# Altium schematic sheet Sheet_all.SchDoc(Sheet_all)
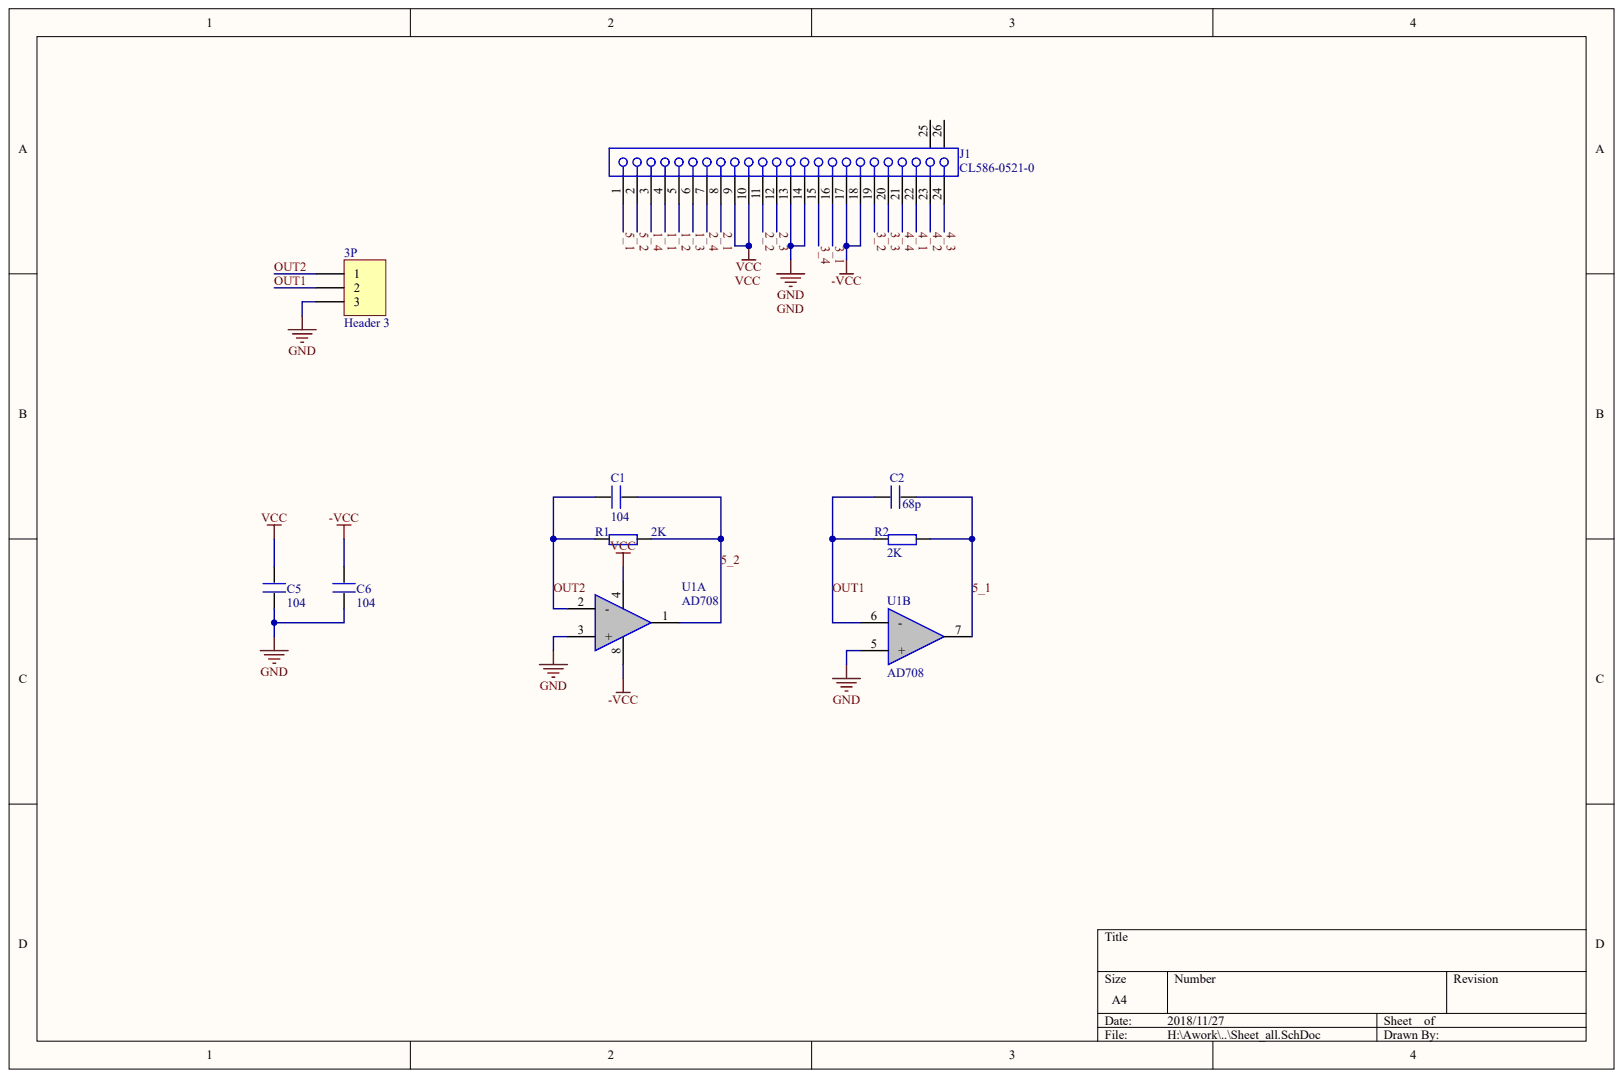
[diagram p0: Sheet_all.SchDoc]

## Parts
3P  Header 3
C1  104
C2  68p
C5  104
C6  104
J1  CL586-0521-0
R1  2K
R2  2K
U1  AD708  (parts A,B)

## Nets
-VCC: C6.2 J1.17 J1.18 U1.8
1_1: J1.4
1_2: J1.5
1_3: J1.6
1_4: J1.3
2_1: J1.8
2_2: J1.11
2_3: J1.12
2_4: J1.7
3_1: J1.16
3_2: J1.19
3_3: J1.20
3_4: J1.15
4_1: J1.22
4_2: J1.23
4_3: J1.24
4_4: J1.21
5_1: C2.2 J1.1 R2.2 U1.7
5_2: C1.2 J1.2 R1.2 U1.1
GND: 3P.3 C5.1 C6.1 J1.13 J1.14 U1.3(+) U1.5(+)
OUT1: 3P.2 C2.1 R2.1 U1.6(-)
OUT2: 3P.1 C1.1 R1.1 U1.2(-)
VCC: C5.2 J1.9 J1.10 U1.4

Not connected: J1 25 26

## Library data of the parts
J1 = (no symbol name): Positions: 24; ContactMaterial: Phosphor Bronze; Current: 0.4A; PCB_Layout: Complies with manufacturer's recommendation.; Published: 17-Jul-2002; DatasheetDocument: 13-Nov-2000; PackageDocument: 13-Nov-2000; PackageReference: FH12-24S-0.5SH; PadDimensions: Follow nominal values, Suitable for Wave Soldering, Adjust for Re-flow.; ComponentLink1Description: Manufacturer Link; ComponentLink1URL: http://www.hiroseusa.com/; ComponentLink2Description: Datasheet; ComponentLink2URL: http://www.hirose.co.jp/cataloge_hp/e58605370.pdf; Publisher: Altium Limited
3P C1 C2 C5 C6 R1 R2 U1 = (no symbol name)
  3P: LatestRevisionDate: 17-Jul-2002; LatestRevisionNote: Re-released for DXP Platform.; Publisher: Altium Limited
